# Altium schematic sheet 8_A9912FH-PCB2-AUX3V3_V1.SchDoc(8_A9912FH-PCB2-AUX3V3_V1)
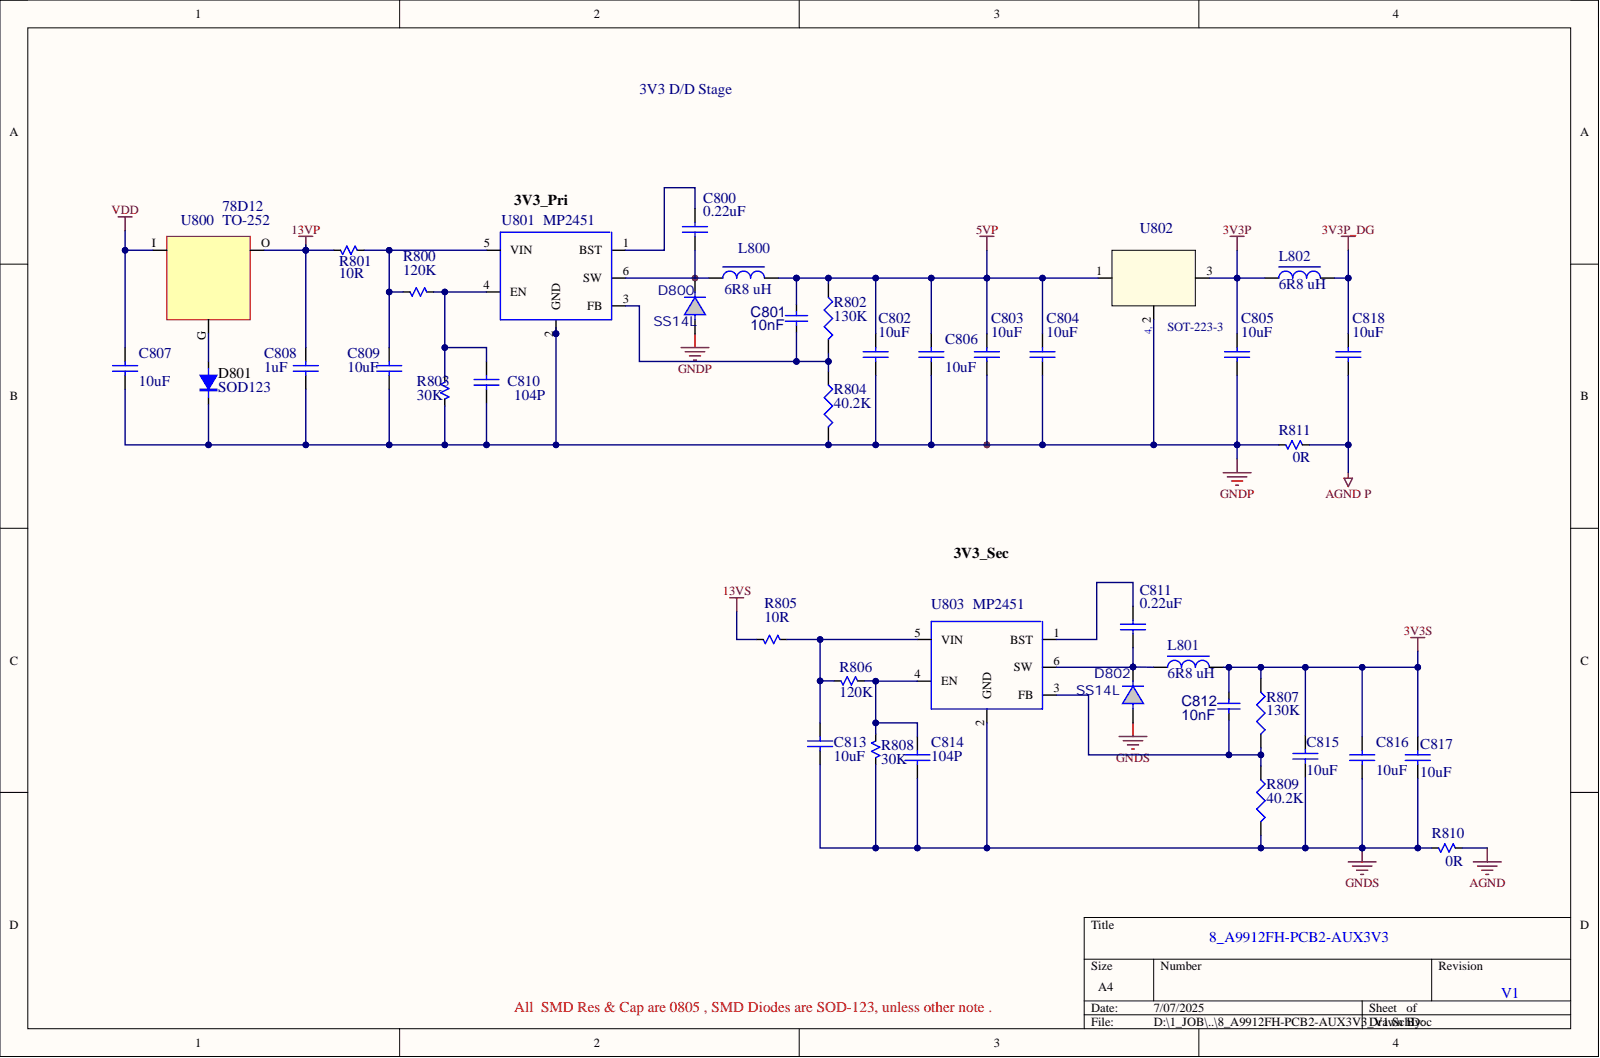
[diagram p0: 8_A9912FH-PCB2-AUX3V3_V1.SchDoc]

## Parts
C800  0.22uF  [CS-0805]
C801  10nF  [CS-0805]
C802  Parameter 1: 10uF  [CS-0805]  (hidden comment: 6.3V)
C803  Parameter 1: 10uF  [CS-0805]  (hidden comment: 6.3V)
C804  Parameter 1: 10uF  [CS-0805]  (hidden comment: 6.3V)
C805  Parameter 1: 10uF  [CS-0805]  (hidden comment: 6.3V)
C806  10uF  [CS-0805]
C807  10uF  [CS-0805]
C808  1uF  [CS-0805]
C809  10uF  [CS-0805]
C810  104P  [CS-0805]
C811  0.22uF  [CS-0805]
C812  10nF  [CS-0805]
C813  10uF  [CS-0805]
C814  104P  [CS-0805]
C815  Parameter 1: 10uF  [CS-0805]  (hidden comment: 6.3V)
C816  10uF  [CS-0805]
C817  Parameter 1: 10uF  [CS-0805]  (hidden comment: 6.3V)
C818  Parameter 1: 10uF  [CS-0805]  (hidden comment: 6.3V)
D800  SS14L  [DS-SMAL]
D801  SOD123  [DS-SOD123FL]
D802  SS14L  [DS-SmaL]
L800  6R8 uH  [LS-4_4X4]
L801  6R8 uH  [LS-4_4X4]
L802  6R8 uH  [LS-4_4X4]
R800  120K  [RS-0805]
R801  10R  [RS-0805]
R802  130K  [RS-0805]
R803  30K  [RS-0805]
R804  40.2K  [RS-0805]
R805  10R  [RS-0805]
R806  120K  [CS-0805]
R807  130K  [RS-0805]
R808  30K  [RS-0805]
R809  40.2K  [RS-0805]
R810  0R  [RS-0805]
R811  0R  [RS-0805]
U800  78D12  psrsmeter 1: TO-252  [IC-TO252-IGO]
U801  MP2451  [IC-SOT23-6]
U802  IC_3_1  [IC-SOT223-123]  (value not drawn)
U803  MP2451  [IC-SOT23-6]

## Nets
3V3P: C805.2 L802.1 U802.3
3V3P_DG: C818.2 L802.2
3V3S: C812.1 C815.2 C816.2 C817.2 L801.2 R807.1
5VP: C801.1 C802.2 C803.2 C804.2 C806.2 L800.2 R802.1 U802.1
13VP: C808.2 R801.1 U800.O
13VS: R805.1
AGND: R810.1
AGND P: C818.1 R811.1
GNDP: C802.1 C803.1 C804.1 C805.1 C806.1 C807.1 C808.1 C809.1 C810.1 D800.A D801.k R803.1 R804.2 R811.2 U801.2(GND) U802.2
GNDS: C813.1 C814.1 C815.1 C816.1 C817.1 D802.A R808.2 R809.2 R810.2 U803.2(GND)
VDD: C807.2 U800.I
NetC800_1: C800.1 D800.K L800.1 U801.6(SW)
NetC800_2: C800.2 U801.1(BST)
NetC801_2: C801.2 R802.2 R804.1 U801.3(FB)
NetC809_2: C809.2 R800.1 R801.2 U801.5(VIN)
NetC810_2: C810.2 R800.2 R803.2 U801.4(EN)
NetC811_1: C811.1 D802.K L801.1 U803.6(SW)
NetC811_2: C811.2 U803.1(BST)
NetC812_2: C812.2 R807.2 R809.1 U803.3(FB)
NetC813_2: C813.2 R805.2 R806.2 U803.5(VIN)
NetC814_2: C814.2 R806.1 R808.1 U803.4(EN)
NetD801_a: D801.a U800.G

## Library data of the parts
C800 C811 = CAP: Ceramic capacitor SMD 0.22uF 25V X7R 0603 10%; Component Kind: Standard; Library Name: Components.SchLib; Parameter 1: 25V
C801 = C0805: Chip capacitor. 10nF, 25V, 0603, X7R; Voltage: 0805; Component Kind: Standard; Library Name: SMD-R.IntLib; PCB3D: C0805(3D)
C802-C805 C815 C817 C818 = CAP: Ceramic capacitor SMD 10u 6.3V X7R 0805 10%; Parameter 2: 0805; Component Kind: Standard; Library Name: Components.SchLib
C806-C808 C810 C813 C814 C816 = CAP: Chip capacitor SMD 1u 25V X7R 0603 10%; Component Kind: Standard; Library Name: Components.SchLib; Parameter 1: 25V
C809 = CAP: Chip capacitor SMD 1u 25V X7R 0603 10%; Parameter 1: 0805; Component Kind: Standard; Library Name: Components.SchLib
C812 = C0805: Chip capacitor. 10nF, 25V, 0603, X7R; Component Kind: Standard; Library Name: SMD-R.IntLib; PCB3D: C0805(3D); Voltage: 25V
D800 D802 = Diode: Diode 100V/0.15A SOD-123; Component Kind: Standard; Library Name: SMD-R.IntLib
  D800: package: SOD-123
  D802: package: SD-123
D801 = DIODE: parameter: SOD-123; Component Kind: Standard; Description: Diode; Library Name: A060212-TD1.SCHLIB
L800-L802 = L_COL: L; Component Kind: Standard; Library Name: COIL-SCH.SCHLIB
R800 R801 R803 R805 R806 R808 = R0805: Chip resistor, 10R, 1% 0805; Parameter 1: 0805; Component Kind: Standard; Library Name: T16.SchLib
R802 R807 = Res: Chip resistor, 182K, 1% 0603; Component Kind: Standard; Library Name: Components.SchLib
R804 R809 = Res: Chip resistor, 59k, 1% 0603; Component Kind: Standard; Library Name: Components.SchLib
R810 R811 = R0805: Chip resistor, 0R, 1% 0603; Parameter 1: 0805; Component Kind: Standard; Library Name: T16.SchLib
U800 = IC_3_IGO: Component Kind: Standard; Library Name: IC-SCH.SCHLIB
U801 U803 = IC-MP2451: 36V 0.6A DC/DC converter; Component Kind: Standard; Library Name: IC SCH.SchLib
U802 = IC_3_1: Component Kind: Standard; Library Name: IC-SCH.SCHLIB
